# Altium schematic sheet Sheet1.SchDoc(Sheet1)
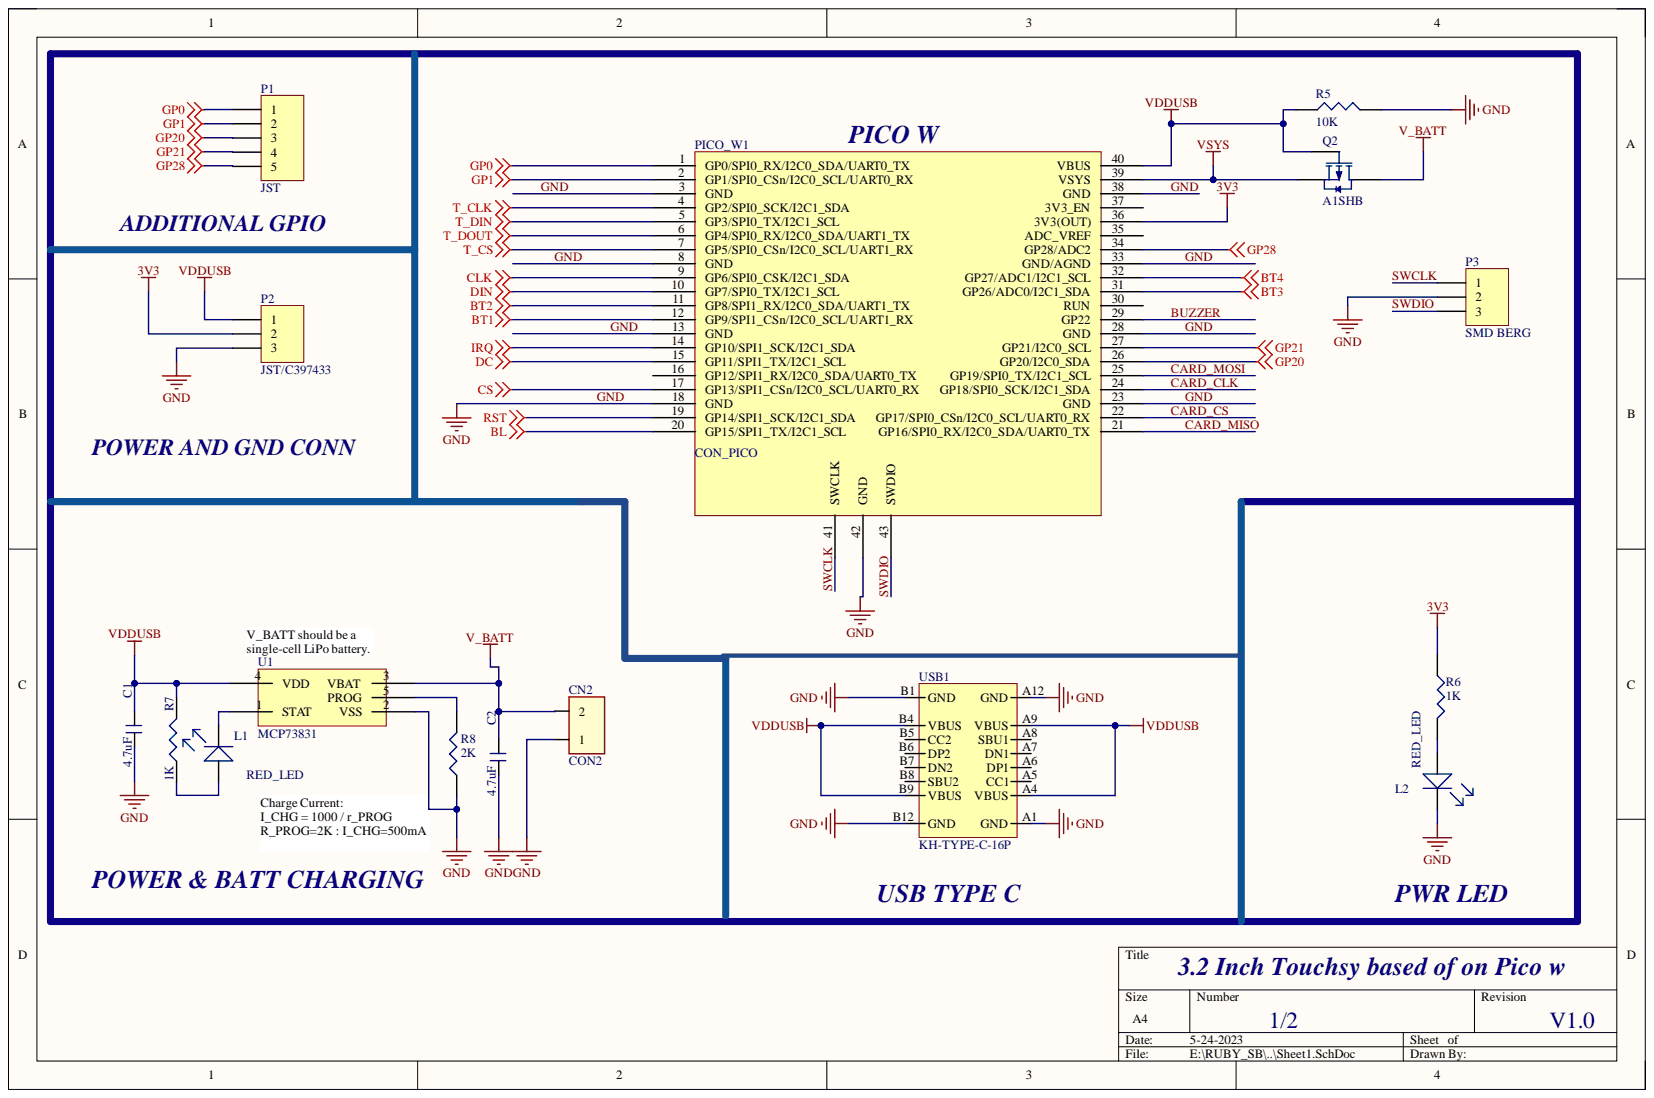
[diagram p0: Sheet1.SchDoc]

## Parts
C1  4.7uF  [C_0603]
C2  4.7uF  [C_0603]
CN2  CON2  [JST2P_P2.0mm]
L1  RED_LED  [LED_0603]
L2  RED_LED  [LED_0603]
P1  JST  [5P JST 2.5MM]
P2  JST/C397433  [3pin jst 2.5mm]
P3  SMD BERG  [SMD_3P BERG]
PICO_W1  CON_PICO  [PICO_W]
Q2  A1SHB  [BSS84]
R5  10K  [R_0603]
R6  1K  [R_0603]
R7  1K  [R_0603]
R8  2K  [R_0603]
U1  MCP73831  [SOT23-5]
USB1  KH-TYPE-C-16P  [USBTYPE-C-31-M-12]

## Nets
3V3: P2.2 PICO_W1.36(3V3(OUT)) R6.1
BL: PICO_W1.20(GP15/SPI1_TX/I2C1_SCL)
BT1: PICO_W1.12(GP9/SPI1_CSn/I2C0_SCL/UART1_RX)
BT2: PICO_W1.11(GP8/SPI1_RX/I2C0_SDA/UART1_TX)
BT3: PICO_W1.31(GP26/ADC0/I2C1_SDA)
BT4: PICO_W1.32(GP27/ADC1/I2C1_SCL)
CLK: PICO_W1.9(GP6/SPI0_CSK/I2C1_SDA)
CS: PICO_W1.17(GP13/SPI1_CSn/I2C0_SCL/UART0_RX)
DC: PICO_W1.15(GP11/SPI1_TX/I2C1_SCL)
DIN: PICO_W1.10(GP7/SPI0_TX/I2C1_SCL)
GP0: P1.1 PICO_W1.1(GP0/SPI0_RX/I2C0_SDA/UART0_TX)
GP1: P1.2 PICO_W1.2(GP1/SPI0_CSn/I2C0_SCL/UART0_RX)
GP20: P1.3 PICO_W1.26(GP20/I2C0_SDA)
GP21: P1.4 PICO_W1.27(GP21/I2C0_SCL)
GP28: P1.5 PICO_W1.34(GP28/ADC2)
IRQ: PICO_W1.14(GP10/SPI1_SCK/I2C1_SDA)
RST: PICO_W1.19(GP14/SPI1_SCK/I2C1_SDA)
T_CLK: PICO_W1.4(GP2/SPI0_SCK/I2C1_SDA)
T_CS: PICO_W1.7(GP5/SPI0_CSn/I2C0_SCL/UART1_RX)
T_DIN: PICO_W1.5(GP3/SPI0_TX/I2C1_SCL)
T_DOUT: PICO_W1.6(GP4/SPI0_RX/I2C0_SDA/UART1_TX)
V_BATT: C2.1 CN2.2 Q2.3 U1.3(VBAT)
VDDUSB: C1.1 P2.1 PICO_W1.40(VBUS) Q2.1 R5.1 R7.2 U1.4(VDD) USB1.A4(VBUS) USB1.A9(VBUS) USB1.B4(VBUS) USB1.B9(VBUS)
VSYS: PICO_W1.39(VSYS) Q2.2
BUZZER: PICO_W1.29(GP22)
CARD_CLK: PICO_W1.24(GP18/SPI0_SCK/I2C1_SDA)
CARD_CS: PICO_W1.22(GP17/SPI0_CSn/I2C0_SCL/UART0_RX)
CARD_MISO: PICO_W1.21(GP16/SPI0_RX/I2C0_SDA/UART0_TX)
CARD_MOSI: PICO_W1.25(GP19/SPI0_TX/I2C1_SCL)
GND: C1.2 C2.2 CN2.1 L2.K P2.3 P3.2 PICO_W1.3(GND) PICO_W1.8(GND) PICO_W1.13(GND) PICO_W1.18(GND) PICO_W1.23(GND) PICO_W1.28(GND) PICO_W1.33(GND/AGND) PICO_W1.38(GND) PICO_W1.42(GND) R5.2 R8.1 U1.2(VSS) USB1.A1(GND) USB1.A12(GND) USB1.B1(GND) USB1.B12(GND)
SWCLK: P3.1 PICO_W1.41(SWCLK)
SWDIO: P3.3 PICO_W1.43(SWDIO)
NetL1_A: L1.A R7.1
NetL1_K: L1.K U1.1(STAT)
NetL2_A: L2.A R6.2
NetR8_2: R8.2 U1.5(PROG)

Not connected: PICO_W1 16(GP12/SPI1_RX/I2C0_SDA/UART0_TX) 30(RUN) 35(ADC_VREF) 37(3V3_EN); USB1 A5(CC1) A6(DP1) A7(DN1) A8(SBU1) B5(CC2) B6(DP2) B7(DN2) B8(SBU2)

## Library data of the parts
C1 C2 = CAP_NONPOLAR: Component Kind: Standard; Library Name: dxp_pasl_lib.IntLib
L1 L2 = LED: Component Kind: Standard; Library Name: dxp_pasl_lib.IntLib
P1 = Header 5: Header, 5-Pin; Component Kind: Standard; LatestRevisionDate: 17-Jul-2002; LatestRevisionNote: Re-released for DXP Platform.; Library Name: Miscellaneous Connectors.IntLib; Signal Integrity: Connector; Publisher: Altium Limited
P2 P3 = Header 3: Header, 3-Pin; Component Kind: Standard; LatestRevisionDate: 17-Jul-2002; LatestRevisionNote: Re-released for DXP Platform.; Library Name: Miscellaneous Connectors.IntLib; Signal Integrity: Connector; Publisher: Altium Limited
PICO_W1 = PICO W: Component Kind: Standard; Library Name: MIX.SchLib
Q2 = BSS84: 50V 130mA 250mW 10Ω 10V,130mA 2V 1mA P Channel SOT-23(SOT-23-3) MOSFETs ROHS #C493579; PackageDocument: 1995/1996; CATEGORY: MOSFET; Component Kind: Standard; LatestRevisionDate: 17-Jul-2002; LatestRevisionNote: Re-released for DXP Platform.; PackageReference: E3; Published: 8-Jun-2000; Library Name: dxp_pasl_lib.IntLib; Publisher: Altium Limited
R5-R8 = RES: Component Kind: Standard; Library Name: dxp_pasl_lib.IntLib
USB1 = USB TYPE-C-31-M-12: 250mA 1 16 Female Type-C SMD USB Connectors ROHS  #  C165948; Component Kind: Standard; ComponentLink1Description: New link; ComponentLink1URL: https://lcsc.com/product-detail/USB-Connectors_Korean-Hroparts-Elec-TYPE-C-31-M-12_C165948.html; Supplier 1: LCSC; Supplier Part Number 1: C165948; Library Name: dxp_pasl_lib.IntLib
CN2 = CON2: Component Kind: Standard; Library Name: dxp_pasl_lib.IntLib
U1 = MCP73831: Component Kind: Standard; Library Name: dxp_pasl_lib.IntLib
